# Altium schematic sheet Mega+ESP.SchDoc
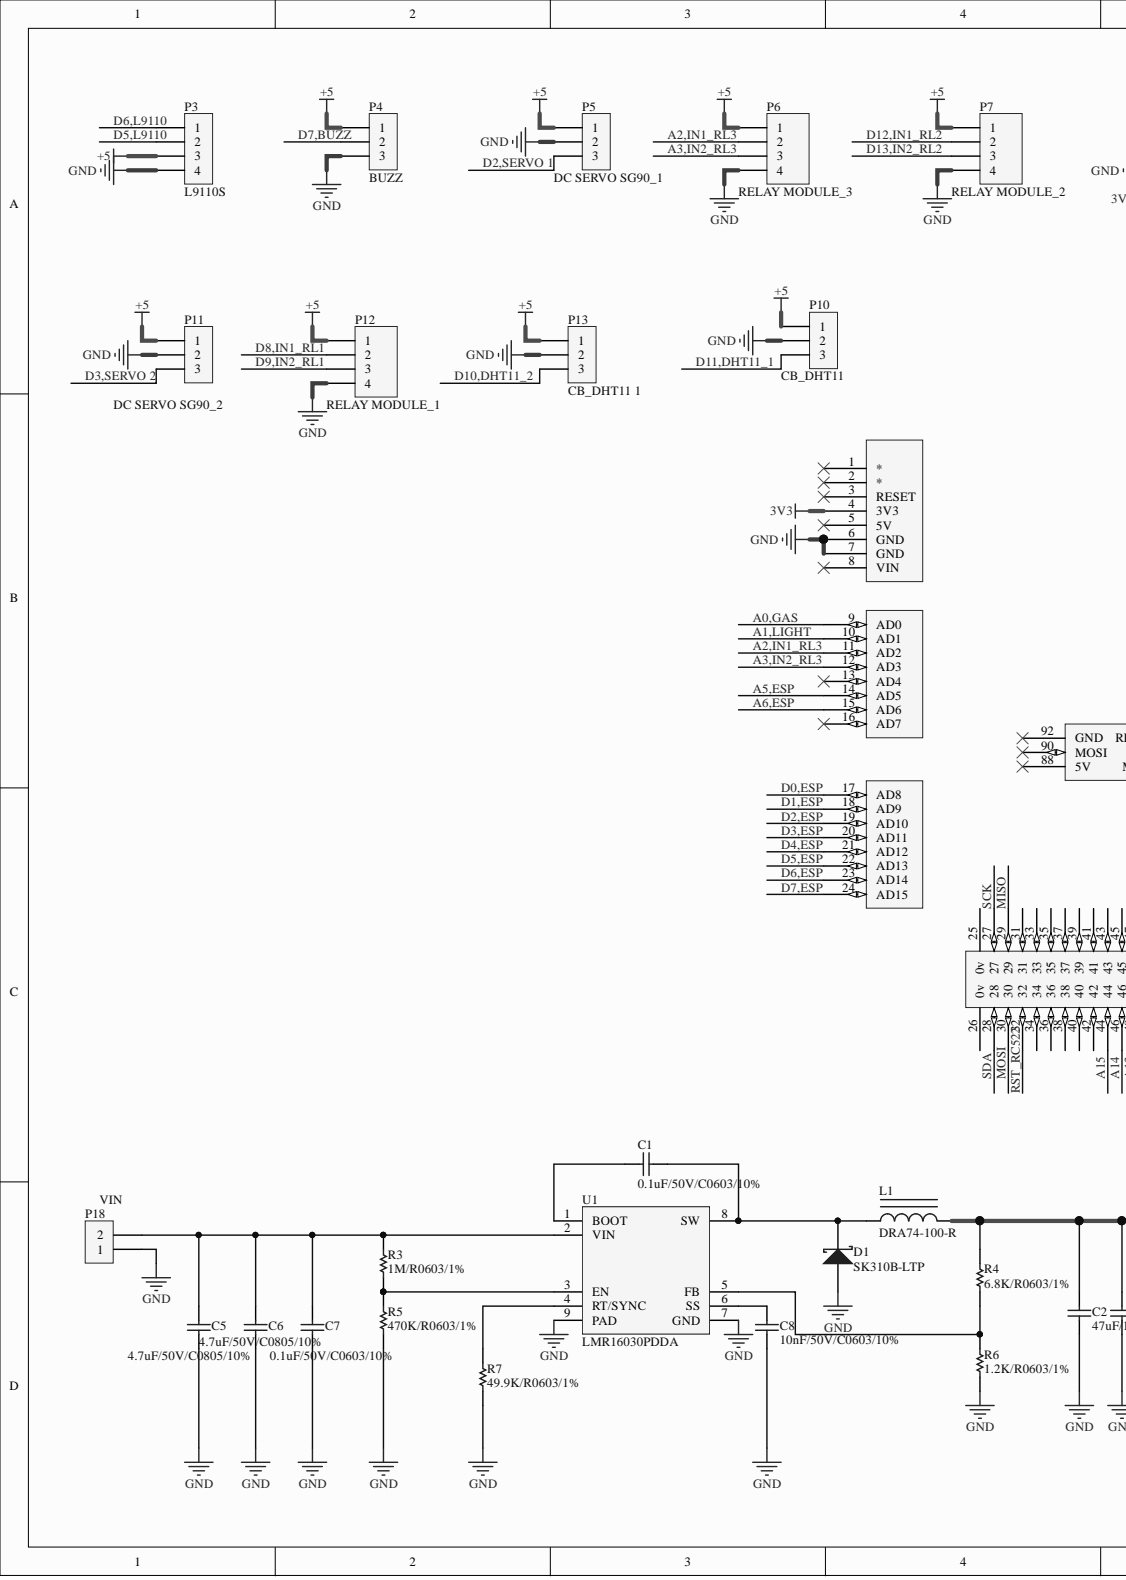
[diagram p0: Mega+ESP.SchDoc, left]
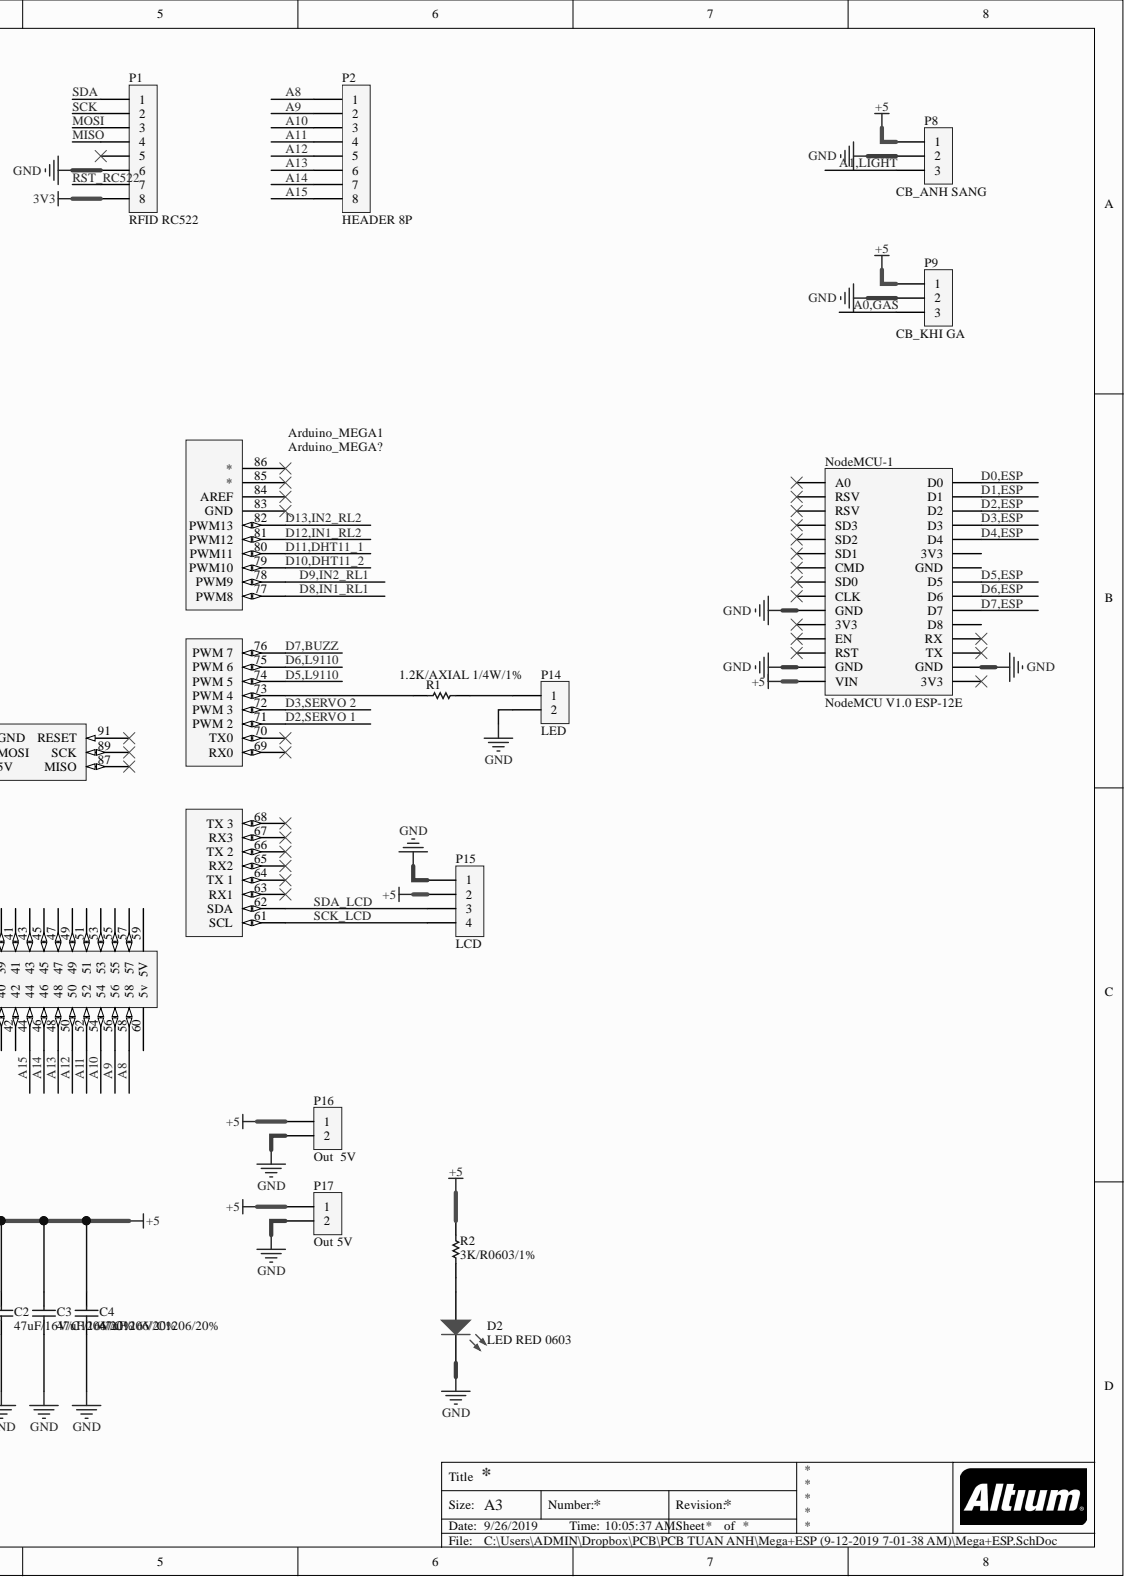
[diagram p1: Mega+ESP.SchDoc, right]

## Parts
Arduino_MEGA1  Arduino_MEGA?  [Arduino Mega]
C1  0.1uF/50V/C0603/10%  [C0603]
C2  47uF/16V/C1206/20%  [C1206]
C3  47uF/16V/C1206/20%  [C1206]
C4  47uF/16V/C1206/20%  [C1206]
C5  4.7uF/50V/C0805/10%  [C0805]
C6  4.7uF/50V/C0805/10%  [C0805]
C7  0.1uF/50V/C0603/10%  [C0603]
C8  10nF/50V/C0603/10%  [C0603]
D1  SK310B-LTP  [SMB (DO-214AA)]
D2  LED RED 0603  [LED RED 0603]
L1  DRA74-100-R  [INDUCTOR SMD DRA74 7.6X7.6X4.35mm]
NodeMCU-1  NodeMCU V1.0 ESP-12E  [NODEMCU V1.0 ESP-12E]
P1  RFID RC522  [HDR1X8]
P2  HEADER 8P  [HDR1X8]
P3  L9110S  [Domino P3.81-4Pin]
P4  BUZZ  [Domino P3.81-3Pin]
P5  DC SERVO SG90_1  [HDR1X3]
P6  RELAY MODULE_3  [Module Relay 2CH]
P7  RELAY MODULE_2  [Module Relay 2CH]
P8  CB_ANH SANG  [Domino P3.81-3Pin]
P9  CB_KHI GA  [Domino P3.81-3Pin]
P10  CB_DHT11  [Domino P3.81-3Pin]
P11  DC SERVO SG90_2  [HDR1X3]
P12  RELAY MODULE_1  [Module Relay 2CH]
P13  CB_DHT11 1  [Domino P3.81-3Pin]
P14  LED  [Header 5pin]
P15  LCD  [Domino P3.81-4Pin]
P16  Out  5V  [Domino P3.81-2Pin]
P17  Out 5V  [Domino P3.81-2Pin]
P18  VIN  [Domino P3.81-2Pin]
R1  1.2K/AXIAL 1/4W/1%  [R DIP1/4W-H]
R2  3K/R0603/1%  [R0603]
R3  1M/R0603/1%  [R0603]
R4  6.8K/R0603/1%  [R0603]
R5  470K/R0603/1%  [R0603]
R6  1.2K/R0603/1%  [R0603]
R7  49.9K/R0603/1%  [R0603]
U1  LMR16030PDDA  [SOIC-8-EP]

## Nets
3V3: Arduino_MEGA1.4(3V3) P1.8
+5: C2.2 C3.2 C4.2 L1.2 NodeMCU-1.VIN P3.3 P4.1 P5.1 P6.1 P7.1 P8.1 P9.1 P10.1 P11.1 P12.1 P13.1 P15.2 P16.1 P17.1 R2.1 R4.2
GND: Arduino_MEGA1.6(GND) Arduino_MEGA1.7(GND) C2.1 C3.1 C4.1 C5.1 C6.1 C7.1 C8.1 D1.1 D2.2 NodeMCU-1.GND P1.6 P3.4 P4.3 P5.2 P6.4 P7.4 P8.2 P9.2 P10.2 P11.2 P12.4 P13.2 P14.2 P15.1 P16.2 P17.2 P18.1 R5.1 R6.1 R7.1 U1.7(GND) U1.9(PAD)
A0,GAS: Arduino_MEGA1.9(AD0) P9.3
A1,LIGHT: Arduino_MEGA1.10(AD1) P8.3
A2,IN1_RL3: Arduino_MEGA1.11(AD2) P6.2
A3,IN2_RL3: Arduino_MEGA1.12(AD3) P6.3
A5,ESP: Arduino_MEGA1.14(AD5)
A6,ESP: Arduino_MEGA1.15(AD6)
A8: Arduino_MEGA1.58 P2.1
A9: Arduino_MEGA1.56 P2.2
A10: Arduino_MEGA1.54 P2.3
A11: Arduino_MEGA1.52 P2.4
A12: Arduino_MEGA1.50 P2.5
A13: Arduino_MEGA1.48 P2.6
A14: Arduino_MEGA1.46 P2.7
A15: Arduino_MEGA1.44 P2.8
D0,ESP: Arduino_MEGA1.17(AD8) NodeMCU-1.D0
D1,ESP: Arduino_MEGA1.18(AD9) NodeMCU-1.D1
D2,ESP: Arduino_MEGA1.19(AD10) NodeMCU-1.D2
D2,SERVO 1: Arduino_MEGA1.71(PWM_2) P5.3
D3,ESP: Arduino_MEGA1.20(AD11) NodeMCU-1.D3
D3,SERVO 2: Arduino_MEGA1.72(PWM_3) P11.3
D4,ESP: Arduino_MEGA1.21(AD12) NodeMCU-1.D4
D5,ESP: Arduino_MEGA1.22(AD13) NodeMCU-1.D5
D5,L9110: Arduino_MEGA1.74(PWM_5) P3.2
D6,ESP: Arduino_MEGA1.23(AD14) NodeMCU-1.D6
D6,L9110: Arduino_MEGA1.75(PWM_6) P3.1
D7,BUZZ: Arduino_MEGA1.76(PWM_7) P4.2
D7,ESP: Arduino_MEGA1.24(AD15) NodeMCU-1.D7
D8,IN1_RL1: Arduino_MEGA1.77(PWM8) P12.2
D9,IN2_RL1: Arduino_MEGA1.78(PWM9) P12.3
D10,DHT11_2: Arduino_MEGA1.79(PWM10) P13.3
D11,DHT11_1: Arduino_MEGA1.80(PWM11) P10.3
D12,IN1_RL2: Arduino_MEGA1.81(PWM12) P7.2
D13,IN2_RL2: Arduino_MEGA1.82(PWM13) P7.3
MISO: Arduino_MEGA1.29 P1.4
MOSI: Arduino_MEGA1.30 P1.3
RST_RC522: Arduino_MEGA1.32 P1.7
SCK: Arduino_MEGA1.27 P1.2
SCK_LCD: Arduino_MEGA1.61(SCL) P15.4
SDA: Arduino_MEGA1.28 P1.1
SDA_LCD: Arduino_MEGA1.62(SDA) P15.3
NetArduino_MEGA1_73: Arduino_MEGA1.73(PWM_4) R1.1
NetC1_1: C1.1 U1.1(BOOT)
NetC1_2: C1.2 D1.2 L1.1 U1.8(SW)
NetC5_2: C5.2 C6.2 C7.2 P18.2 R3.2 U1.2(VIN)
NetC8_2: C8.2 U1.6(SS)
NetD2_1: D2.1 R2.2
NetP14_1: P14.1 R1.2
NetR3_1: R3.1 R5.2 U1.3(EN)
NetR4_1: R4.1 R6.2 U1.5(FB)
NetR7_2: R7.2 U1.4(RT/SYNC)

Not connected: Arduino_MEGA1 1(*) 2(*) 3(RESET) 5(5V) 8(VIN) 13(AD4) 16(AD7) 25 26(0v) 31 33 34 35 36 37 38 39 40 41 42 43 45 47 49 51 53 55 57 59 60(5v) 63(RX1) 64(TX_1) 65(RX2) 66(TX_2) 67(RX3) 68(TX_3) 69(RX0) 70(TX0) 83(GND) 84(AREF) 85(*) 86(*) 87(MISO) 88(5V) 89(SCK) 90(MOSI) 91(RESET) 92(GND); NodeMCU-1 3V3 A0 CLK CMD D8 EN RST RSV RX SD0 SD1 SD2 SD3 TX; P1 5

## Library data of the parts
Arduino_MEGA1 = Arduino_MEGA: Component Kind: Standard; Library Name: Sch_Project_2.SchLib
C1 C7 = 0.1uF/50V/C0603/10%: CAP CER 0.1UF 50V 10% X7R 0603; Manufacturer: Murata Electronics North America; Manufacturer Part Number: GRM188R71H104KA93D; Capacitance: 0.1µF; Applications: General Purpose; Category: Capacitors; Component Kind: Standard; ComponentLink1Description: http://www.murata.com/~/media/webrenewal/support/library/catalog/products/capacitor/mlcc/c02e.ashx; ComponentLink1URL: http://www.murata.com/~/media/webrenewal/support/library/catalog/products/capacitor/mlcc/c02e.ashx; Features: -; Height - Seated (Max): -; Lead Spacing: -; Lead Style: -; Mounting Type: Surface Mount, MLCC; Operating Temperature: -55°C ~ 125°C; Package / Case: 0603 (1608 Metric); Packaging: Tape & Reel (TR); Ratings: -; RoHS: RoHS Compliant; Size / Dimension: 0.063" L x 0.031" W (1.60mm x 0.80mm); Supplier 1: Digi-Key; Temperature Coefficient: X7R; Thickness (Max): 0.035" (0.90mm); Tolerance: ±10%; Voltage - Rated: 50V; Library Name: Manh_Capacitor.SchLib
  C1: Supplier Part Number 1: 490-1519-1-ND
  C7: Pricing 1: 4000=0.00759, 8000=0.00693, 12000=0.0066, 28000=0.00627, 100000=0.00454 (USD); Stock 1: 8995972
C2-C4 = 47uF/16V/C1206/20%: CAP CER 47UF 16V X5R 1206; Manufacturer: TDK Corporation; Manufacturer Part Number: C3216X5R1C476M160AB; Capacitance: 47µF; Tolerance: ±20%; Applications: General Purpose; Category: Capacitors; Component Kind: Standard; ComponentLink1Description: http://product.tdk.com/en/catalog/datasheets/mlcc_commercial_general_en.pdf; ComponentLink1URL: http://product.tdk.com/en/catalog/datasheets/mlcc_commercial_general_en.pdf; Features: -; Height - Seated (Max): -; Lead Spacing: -; Lead Style: -; Mounting Type: Surface Mount, MLCC; Operating Temperature: -55°C ~ 85°C; Package / Case: 1206 (3216 Metric); Packaging: Cut Tape (CT); Ratings: -; RoHS: RoHS Compliant; Size / Dimension: 0.126" L x 0.063" W (3.20mm x 1.60mm); Supplier 1: Digi-Key; Supplier Part Number 1: 445-6003-1-ND; Temperature Coefficient: X5R; Thickness (Max): 0.071" (1.80mm); Voltage - Rated: 16V; Library Name: Manh_Capacitor.SchLib
C5 C6 = 4.7uF/50V/C0805/10%: CAP CER 4.7UF 50V 10% X5R 0805; Manufacturer: TDK Corporation; Manufacturer Part Number: CGA4J3X5R1H475K125AB; Capacitance: 4.7µF; Applications: Automotive; Category: Capacitors; Component Kind: Standard; ComponentLink1Description: http://product.tdk.com/en/catalog/datasheets/mlcc_automotive_general_en.pdf; ComponentLink1URL: http://product.tdk.com/en/catalog/datasheets/mlcc_automotive_general_en.pdf; Features: -; Height - Seated (Max): -; Lead Spacing: -; Lead Style: -; Mounting Type: Surface Mount, MLCC; Operating Temperature: -55°C ~ 85°C; Package / Case: 0805 (2012 Metric); Packaging: Tape & Reel (TR); Pricing 1: 2000=0.176, 4000=0.165, 10000=0.154, 14000=0.15125, 50000=0.143, 100000=0.1375 (USD); Ratings: AEC-Q200; RoHS: RoHS Compliant; Size / Dimension: 0.079" L x 0.049" W (2.00mm x 1.25mm); Stock 1: 10000; Supplier 1: Digi-Key; Temperature Coefficient: X5R; Thickness (Max): 0.049" (1.25mm); Tolerance: ±10%; Voltage - Rated: 50V; Library Name: Manh_Capacitor.SchLib
C8 = 10nF/50V/C0603/10%: CAP CER 10000PF 50V X7R 0603; Manufacturer: Murata Electronics North America; Manufacturer Part Number: GRM188R71H103KA01D; Tolerance: ±10%; Applications: General Purpose; Capacitance: 10000pF; Category: Capacitors; Component Kind: Standard; ComponentLink1Description: http://www.murata.com/~/media/webrenewal/support/library/catalog/products/capacitor/mlcc/c02e.ashx; ComponentLink1URL: http://www.murata.com/~/media/webrenewal/support/library/catalog/products/capacitor/mlcc/c02e.ashx; Features: -; Height - Seated (Max): -; Lead Spacing: -; Lead Style: -; Mounting Type: Surface Mount, MLCC; Operating Temperature: -55°C ~ 125°C; Package / Case: 0603 (1608 Metric); Packaging: Cut Tape (CT); Ratings: -; RoHS: RoHS Compliant; Size / Dimension: 0.063" L x 0.031" W (1.60mm x 0.80mm); Supplier 1: Digi-Key; Supplier Part Number 1: 490-1512-1-ND; Temperature Coefficient: X7R; Thickness (Max): 0.035" (0.90mm); Voltage - Rated: 50V; Library Name: Manh_Capacitor.SchLib
D1 = SK310B-LTP: DIODE SCHOTTKY 100V 3A DO214AA; Manufacturer: Micro Commercial Co; Capacitance @ Vr, F: 250pF @ 4V, 1MHz; Current - Reverse Leakage @ Vr: 500µA @ 100V; Speed: Fast Recovery =< 500ns, > 200mA (Io); Voltage - Forward (Vf) (Max) @ If: 850mV @ 3A; Category: Discrete Semiconductor Products; Component Kind: Standard; ComponentLink1Description: http://www.mccsemi.com/up_pdf/SK32B-L~SK310B-L(DO-214AA).pdf; ComponentLink1URL: http://www.mccsemi.com/up_pdf/SK32B-L~SK310B-L(DO-214AA).pdf; Current - Average Rectified (Io): 3A; Diode Type: Schottky; Manufacturer Part Number: SK310B-LTP; Mounting Type: Surface Mount; Operating Temperature - Junction: -55°C ~ 150°C; Package / Case: DO-214AA, SMB; Packaging: Cut Tape (CT); Part Status: Active; Pricing 1: 1=0.6, 10=0.42, 25=0.3448, 100=0.276, 250=0.20072, 500=0.1631, 1000=0.12545 (USD); Reverse Recovery Time (trr): -; RoHS: RoHS Compliant; Stock 1: 101065; Supplier 1: Digi-Key; Supplier Device Package: DO-214AA (SMB); Supplier Part Number 1: SK310B-LTPMSCT-ND; Voltage - DC Reverse (Vr) (Max): 100V; Library Name: Manh_Diode.SchLib
D2 = LED RED 0603: LED RED CLEAR 0603 SMD; Manufacturer: Lite-On Inc.; Manufacturer Part Number: LTST-C190KRKT; Current - Test: 20mA; Lens Color: Colorless; Lens Style/Size: Rectangle with Flat Top, 1.10mm x 0.80mm; Millicandela Rating: 99mcd; Viewing Angle: 130°; Voltage - Forward (Vf) (Typ): 2V; Wavelength - Dominant: 631nm; Wavelength - Peak: 639nm; Category: Optoelectronics; Color: Red; Component Kind: Standard; ComponentLink1Description: http://optoelectronics.liteon.com/upload/download/DS-22-99-0151/S_110_LTST-C190KRKT.pdf; ComponentLink1URL: http://optoelectronics.liteon.com/upload/download/DS-22-99-0151/S_110_LTST-C190KRKT.pdf; Features: -; Height (Max): 0.80mm; Lens Transparency: Clear; Mounting Type: Surface Mount; Package / Case: 0603 (1608 Metric); Packaging: Digi-Reel®; RoHS: RoHS Compliant; Size / Dimension: 1.60mm L x 0.80mm W; Supplier 1: Digi-Key; Supplier Part Number 1: 160-1436-1-ND; Library Name: Manh_LED.SchLib
L1 = 10uH/2.56A/7.6X7.6X3.55mm: FIXED IND 10UH 2.56A 43 MOHM SMD; Manufacturer: Eaton Bussmann; Current - Saturation: 2.97A; DC Resistance (DCR): 43 mOhm; Frequency - Test: 100kHz; Inductance: 10µH; Shielding: Shielded; Tolerance: ±20%; Type: Wirewound; Category: Inductors, Coils, Chokes; Component Kind: Standard; ComponentLink1Description: http://www1.cooperbussmann.com/pdf/9190a0eb-f996-4faf-a4d6-7b76f954f32d.pdf; ComponentLink1URL: http://www1.cooperbussmann.com/pdf/9190a0eb-f996-4faf-a4d6-7b76f954f32d.pdf; Current Rating: 2.56A; Frequency - Self Resonant: -; Height - Seated (Max): 0.139" (3.55mm); Manufacturer Part Number: DRA74-100-R; Material - Core: -; Mounting Type: Surface Mount; Operating Temperature: -40°C ~ 165°C; Package / Case: Nonstandard; Packaging: Digi-Reel®; Q @ Freq: -; RoHS: RoHS Compliant; Size / Dimension: 0.299" L x 0.299" W (7.60mm x 7.60mm); Supplier 1: Digi-Key; Supplier Device Package: -; Supplier Part Number 1: 283-3623-1-ND; Library Name: Manh_Inductor.SchLib
NodeMCU-1 = NodeMCU V1.0 ESP-12E: NodeMCU V1.0 ESP8266 (ESP-12E); Component Kind: Standard; Library Name: Sch_Project_2.SchLib
P1 = DOMINO_8P_3.81MM: TERM BLK 8P SIDE ENT 3.81MM PCB; Manufacturer 1: Phoenix Contact; Manufacturer Part Number 1: 1727078; Approvals: UL; Connector Type: Screw; Current: 13.5A; Max Current Rating: 12A; Max Supply Voltage: 160V; Number of Circuits: 8; Number of Levels: 1; Stripping Length: 5mm; Test Voltage: 2.5kV; Voltage: 300V; Wire/Cable Gauge: 26AWG; Wire Gauge (Min): 16AWG; Color: Green; Component Kind: Standard; ComponentLink1Description: https://datasheet.ciiva.com/15169/getdatasheetpartid-177912-15169668.pdf?src-supplier=Verical; ComponentLink1URL: https://datasheet.ciiva.com/15169/getdatasheetpartid-177912-15169668.pdf?src-supplier=Verical; ComponentLink2Description: https://datasheet.ciiva.com/16071/1727078-16071832.pdf?src-supplier=Onlinecomponents.com; ComponentLink2URL: https://datasheet.ciiva.com/16071/1727078-16071832.pdf?src-supplier=Onlinecomponents.com; ComponentLink3Description: https://datasheet.ciiva.com/823/1727078-823829.pdf?src-supplier=Digi-Key; ComponentLink3URL: https://datasheet.ciiva.com/823/1727078-823829.pdf?src-supplier=Digi-Key; ComponentLink4Description: https://datasheet.ciiva.com/1118/100945-1118602.pdf?src-supplier=Element14; ComponentLink4URL: https://datasheet.ciiva.com/1118/100945-1118602.pdf?src-supplier=Element14; Contact Material: Tin; Current Rating: 13.5A; Depth: 7.3mm; Flammability Rating: UL94 V-0; Height: 12mm; Housing Color: Green; Housing Material: Polyamide; Insulation Material: Nylon; Lead Free: Lead Free; Length: 30.47mm; Max Operating Temperature: 100°C; Min Operating Temperature: -20°C; Mount: Through Hole; Number of Contacts: 8; Number of Pins: 8; Number of Poles: 8; Number of Positions: 8; Number of Positions Per Level: 8; Number of Rows: 1; Orientation: Straight; Package Quantity: 50; Packaging: Bulk; Pitch: 3.81mm; Pricing 1: 1=5.00000, 10=4.78200, 50=4.65140, 100=4.34700, 250=3.99924, 500=3.69496, 1000=3.52108, 2500=3.30374 (USD); Radiation Hardening: No; REACH SVHC: No SVHC; RoHS: Yes; Stock 1: 5691; Supplier 1: Digi-Key; Supplier Part Number 1: 277-1953-ND; Termination: Screw; Voltage Rating: 300V; Weight: 0.15062oz; Width: 30.47mm; Wire Gauge (Max): 26AWG; Library Name: Manh_Connector.SchLib
P2 = HEADER VERT 8POS 2.54MM: CONN HEADER VERT 8POS 2.54MM; Component Kind: Standard; Library Name: Manh_Connector.SchLib
P3 P15 = DOMINO_4P_3.81MM: TERM BLK 4P SIDE ENT 3.81MM PCB; Manufacturer 1: Phoenix Contact; Manufacturer Part Number 1: 1727036; Approvals: UL; Connector Type: Screw; Current: 13.5A; Max Current Rating: 12A; Max Supply Voltage: 160V; Number of Circuits: 4; Number of Levels: 1; Test Voltage: 2.5kV; Voltage: 300V; Wire/Cable Gauge: 26AWG; Color: Green; Component Kind: Standard; ComponentLink1Description: https://datasheet.ciiva.com/16071/1727036-16071822.pdf?src-supplier=Onlinecomponents.com; ComponentLink1URL: https://datasheet.ciiva.com/16071/1727036-16071822.pdf?src-supplier=Onlinecomponents.com; ComponentLink2Description: https://datasheet.ciiva.com/824/1727036-824335.pdf?src-supplier=Digi-Key; ComponentLink2URL: https://datasheet.ciiva.com/824/1727036-824335.pdf?src-supplier=Digi-Key; ComponentLink3Description: https://datasheet.ciiva.com/1120/1699405-1120565.pdf?src-supplier=Farnell; ComponentLink3URL: https://datasheet.ciiva.com/1120/1699405-1120565.pdf?src-supplier=Farnell; ComponentLink4Description: https://datasheet.ciiva.com/15169/getdatasheetpartid-136938-15169499.pdf?src-supplier=Verical; ComponentLink4URL: https://datasheet.ciiva.com/15169/getdatasheetpartid-136938-15169499.pdf?src-supplier=Verical; ComponentLink5Description: https://datasheet.ciiva.com/1118/1699405-1118598.pdf?src-supplier=Element14; ComponentLink5URL: https://datasheet.ciiva.com/1118/1699405-1118598.pdf?src-supplier=Element14; Contact Material: Tin; Current Rating: 13.5A; Depth: 7.3mm; Flammability Rating: UL94 V-0; Height: 12mm; Housing Color: Green; Housing Material: Polyamide; Insulation Material: Nylon; Lead Free: Lead Free; Length: 7.3mm; Max Operating Temperature: 100°C; Min Operating Temperature: -20°C; Mount: Through Hole; Number of Contacts: 4; Number of Pins: 4; Number of Poles: 4; Number of Positions: 4; Number of Positions Per Level: 4; Number of Rows: 1; Orientation: Straight; Package Quantity: 50; Packaging: Bulk; Pitch: 3.81mm; Pricing 1: 1=2.58000, 10=2.47500, 50=2.36780, 100=2.30320, 250=2.15252, 500=1.98030, 1000=1.82962, 2500=1.74354, 5000=1.63590 (USD); Radiation Hardening: No; REACH SVHC: No SVHC; RoHS: Yes; Stock 1: 1801; Supplier 1: Digi-Key; Supplier Part Number 1: 277-1949-ND; Termination: Screw; Voltage Rating: 300V; Weight: 0.070548oz; Width: 15.23mm; Wire Gauge (Max): 26AWG; Wire Gauge (Min): 26AWG; Library Name: Manh_Connector.SchLib
P4 P5 P8-P11 P13 = DOMINO_3P_3.81MM: TERM BLK 3P SIDE ENT 3.81MM PCB; Manufacturer 1: Phoenix Contact; Manufacturer Part Number 1: 1727023; Approvals: UL; Connector Type: Screw; Current: 13.5A; Max Current Rating: 12A; Max Supply Voltage: 160V; Number of Circuits: 3; Number of Levels: 1; Voltage: 300V; Wire/Cable Gauge: 26AWG; Wire Gauge (Max): 30AWG; Wire Gauge (Min): 16AWG; Color: Green; Component Kind: Standard; ComponentLink1Description: https://datasheet.ciiva.com/7053/100945-7053104.pdf?src-supplier=Newark; ComponentLink1URL: https://datasheet.ciiva.com/7053/100945-7053104.pdf?src-supplier=Newark; ComponentLink2Description: https://datasheet.ciiva.com/1120/1718832-1120564.pdf?src-supplier=Farnell; ComponentLink2URL: https://datasheet.ciiva.com/1120/1718832-1120564.pdf?src-supplier=Farnell; ComponentLink3Description: https://datasheet.ciiva.com/824/1727023-824310.pdf?src-supplier=Digi-Key; ComponentLink3URL: https://datasheet.ciiva.com/824/1727023-824310.pdf?src-supplier=Digi-Key; ComponentLink4Description: https://datasheet.ciiva.com/16071/1727023-16071820.pdf?src-supplier=Onlinecomponents.com; ComponentLink4URL: https://datasheet.ciiva.com/16071/1727023-16071820.pdf?src-supplier=Onlinecomponents.com; Contact Material: Tin; Current Rating: 13.5A; Depth: 7.3mm; Flammability Rating: UL94 V-0; Height: 12mm; Housing Color: Green; Housing Material: Polyamide; Insulation Material: Nylon; Lead Free: Lead Free; Length: 7.3mm; Mount: Through Hole; Number of Contacts: 3; Number of Pins: 3; Number of Poles: 3; Number of Positions: 3; Number of Positions Per Level: 3; Number of Rows: 1; Orientation: Straight; Package Quantity: 50; Packaging: Bulk; Pitch: 3.81mm; Pricing 1: 1=1.94000, 10=1.86000, 50=1.77880, 100=1.73020, 250=1.61700, 500=1.48764, 1000=1.37445, 2500=1.30978, 5000=1.22893 (USD); REACH SVHC: Unknown; RoHS: Yes; Stock 1: 2285; Supplier 1: Digi-Key; Supplier Part Number 1: 277-1948-ND; Termination: Screw; Voltage Rating: 300V; Weight: 0.058202oz; Width: 12mm; Library Name: Manh_Connector.SchLib
P6 P7 P12 = Header 4: Header, 4-Pin; Component Kind: Standard; LatestRevisionDate: 17-Jul-2002; LatestRevisionNote: Re-released for DXP Platform.; Library Name: Miscellaneous Connectors.IntLib; Signal Integrity: Connector; Publisher: Altium Limited
P14 P16-P18 = DOMINO_2P_3.81MM: TERM BLK 2P SIDE ENT 3.81MM PCB; Manufacturer 1: Phoenix Contact; Manufacturer Part Number 1: 1727010; Approvals: UL; Connector Type: Screw; Current: 13.5A; Max Current Rating: 12A; Max Supply Voltage: 160V; Number of Circuits: 2; Number of Levels: 1; Voltage: 300V; Wire/Cable Gauge: 26AWG; Wire Gauge (Min): 16AWG; Color: Green; Component Kind: Standard; ComponentLink1Description: https://datasheet.ciiva.com/824/1727010-824277.pdf?src-supplier=Digi-Key; ComponentLink1URL: https://datasheet.ciiva.com/824/1727010-824277.pdf?src-supplier=Digi-Key; ComponentLink2Description: https://datasheet.ciiva.com/15216/getdatasheetpartid-227074-15216409.pdf?src-supplier=Verical; ComponentLink2URL: https://datasheet.ciiva.com/15216/getdatasheetpartid-227074-15216409.pdf?src-supplier=Verical; ComponentLink3Description: https://datasheet.ciiva.com/16046/1727010-16046140.pdf?src-supplier=Onlinecomponents.com; ComponentLink3URL: https://datasheet.ciiva.com/16046/1727010-16046140.pdf?src-supplier=Onlinecomponents.com; ComponentLink4Description: https://datasheet.ciiva.com/26341/788566-da-01-cs-printklemme-1727010-250-st-26341111.pdf?src-supplier=Conrad; ComponentLink4URL: https://datasheet.ciiva.com/26341/788566-da-01-cs-printklemme-1727010-250-st-26341111.pdf?src-supplier=Conrad; ComponentLink5Description: https://datasheet.ciiva.com/1118/100945-1118596.pdf?src-supplier=Element14; ComponentLink5URL: https://datasheet.ciiva.com/1118/100945-1118596.pdf?src-supplier=Element14; Contact Material: Tin; Current Rating: 13.5A; Depth: 7.3mm; Flammability Rating: UL94 V-0; Height: 12mm; Housing Color: Green; Housing Material: Polyamide; Insulation Material: Nylon; Lead Free: Lead Free; Length: 7.3mm; Mount: Through Hole; Number of Contacts: 2; Number of Pins: 2; Number of Poles: 2; Number of Positions: 2; Number of Positions Per Level: 2; Number of Rows: 1; Orientation: Straight; Package Quantity: 50; Packaging: Bulk; Pitch: 3.81mm; Pricing 1: 1=1.29000, 10=1.23200, 50=1.17820, 100=1.14600, 250=1.07100, 500=0.98532, 1000=0.91035, 2500=0.86752, 5000=0.81397 (USD); Radiation Hardening: No; REACH SVHC: No SVHC; RoHS: Yes; Stock 1: 2711; Supplier 1: Digi-Key; Supplier Part Number 1: 277-1947-ND; Termination: Solder; Voltage Rating: 300V; Weight: 0.036685oz; Width: 12mm; Wire Gauge (Max): 26AWG; Library Name: Manh_Connector.SchLib
R1 = 100K/AXIAL 1/4W/1%: RES 100K OHM 1/4W 1% AXIAL; Manufacturer: Stackpole Electronics Inc.; Manufacturer Part Number: RNF14FTD100K; Power (Watts): 0.25W, 1/4W; Temperature Coefficient: ±100ppm/°C; Category: Resistors; Component Kind: Standard; ComponentLink1Description: http://www.seielect.com/Catalog/SEI-RNF_RNMF.pdf; ComponentLink1URL: http://www.seielect.com/Catalog/SEI-RNF_RNMF.pdf; Composition: Metal Film; Features: Flame Retardant Coating, Safety; Height: -; Number of Terminations: 2; Operating Temperature: -55°C ~ 155°C; Package / Case: Axial; Packaging: Cut Tape (CT); Resistance (Ohms): 100k; RoHS: RoHS Compliant; Size / Dimension: 0.093" Dia x 0.250" L (2.35mm x 6.35mm); Supplier 1: Digi-Key; Supplier Device Package: Axial; Supplier Part Number 1: RNF14FTD100KCT-ND; Tolerance: ±1%; Library Name: Manh_Resistor.SchLib
R2 = 3K/R0603/1%: RES SMD 3K OHM 1% 1/10W 0603; Manufacturer: Yageo; Manufacturer Part Number: RC0603FR-073KL; Power (Watts): 0.1W, 1/10W; Temperature Coefficient: ±100ppm/°C; Category: Resistors; Component Kind: Standard; ComponentLink1Description: http://www.yageo.com/documents/recent/PYu-RC_Group_51_RoHS_L_7.pdf; ComponentLink1URL: http://www.yageo.com/documents/recent/PYu-RC_Group_51_RoHS_L_7.pdf; Composition: Thick Film; Failure Rate: -; Features: Moisture Resistant; Height - Seated (Max): 0.022" (0.55mm); Operating Temperature: -55°C ~ 155°C; Package / Case: 0603 (1608 Metric); Packaging: Cut Tape (CT); Part Status: Active; Pricing 1: 1=0.1, 10=0.013, 25=0.0096, 50=0.0074, 100=0.0055, 250=0.00416, 500=0.00332, 1000=0.00245 (USD); Resistance (Ohms): 3k; RoHS: RoHS Compliant; Size / Dimension: 0.063" L x 0.031" W (1.60mm x 0.80mm); Stock 1: 1322257; Supplier 1: Digi-Key; Supplier Device Package: 0603; Supplier Part Number 1: 311-3.00KHRCT-ND; Tolerance: ±1%; Library Name: Manh_Resistor.SchLib
R3 = 1M/R0603/1%: RES SMD 1M OHM 1% 1/10W 0603; Manufacturer: Yageo; Manufacturer Part Number: RC0603FR-071ML; Power (Watts): 0.1W, 1/10W; Temperature Coefficient: ±100ppm/°C; Category: Resistors; Component Kind: Standard; ComponentLink1Description: http://www.yageo.com/NewPortal/yageodocoutput?fileName=/pdf/R-Chip/PYu-RC_Group_51_RoHS_L_3.pdf; ComponentLink1URL: http://www.yageo.com/NewPortal/yageodocoutput?fileName=/pdf/R-Chip/PYu-RC_Group_51_RoHS_L_3.pdf; Composition: Thick Film; Features: Moisture Resistant; Height: 0.022" (0.55mm); Number of Terminations: 2; Operating Temperature: -55°C ~ 155°C; Package / Case: 0603 (1608 Metric); Packaging: Cut Tape (CT); Resistance (Ohms): 1M; RoHS: RoHS Compliant; Size / Dimension: 0.063" L x 0.031" W (1.60mm x 0.80mm); Supplier 1: Digi-Key; Supplier Device Package: 0603; Supplier Part Number 1: 311-1.00MHRCT-ND; Tolerance: ±1%; Library Name: Manh_Resistor.SchLib
R4 = 6.8K/R0603/1%: RES SMD 6.8K OHM 1% 1/10W 0603; Manufacturer: Panasonic Electronic Components; Manufacturer Part Number: ERJ-3EKF6801V; Power (Watts): 0.1W, 1/10W; Temperature Coefficient: ±100ppm/°C; Category: Resistors; Component Kind: Standard; ComponentLink1Description: http://industrial.panasonic.com/www-cgi/jvcr13pz.cgi?E+PZ+3+AOA0002+ERJ3EKF6801V+7+WW; ComponentLink1URL: http://industrial.panasonic.com/www-cgi/jvcr13pz.cgi?E+PZ+3+AOA0002+ERJ3EKF6801V+7+WW; Composition: Thick Film; Features: Automotive AEC-Q200; Height: 0.022" (0.55mm); Number of Terminations: 2; Operating Temperature: -55°C ~ 155°C; Package / Case: 0603 (1608 Metric); Packaging: Digi-Reel®; Resistance (Ohms): 6.8k; RoHS: RoHS Compliant; Size / Dimension: 0.063" L x 0.031" W (1.60mm x 0.80mm); Supplier 1: Digi-Key; Supplier Device Package: 0603; Supplier Part Number 1: P6.80KHCT-ND; Tolerance: ±1%; Library Name: Manh_Resistor.SchLib
R5 = 470K/R0603/1%: RES SMD 470K OHM 1% 1/10W 0603; Manufacturer: Yageo; Manufacturer Part Number: RC0603FR-07470KL; Power (Watts): 0.1W, 1/10W; Temperature Coefficient: ±100ppm/°C; Category: Resistors; Component Kind: Standard; ComponentLink1Description: http://www.yageo.com/documents/recent/PYu-RC_Group_51_RoHS_L_7.pdf; ComponentLink1URL: http://www.yageo.com/documents/recent/PYu-RC_Group_51_RoHS_L_7.pdf; Composition: Thick Film; Failure Rate: -; Features: Moisture Resistant; Height - Seated (Max): 0.022" (0.55mm); Operating Temperature: -55°C ~ 155°C; Package / Case: 0603 (1608 Metric); Packaging: Cut Tape (CT); Part Status: Active; Pricing 1: 1=0.1, 10=0.013, 25=0.0096, 50=0.0074, 100=0.0055, 250=0.00416, 500=0.00332, 1000=0.00245 (USD); Resistance (Ohms): 470k; RoHS: RoHS Compliant; Size / Dimension: 0.063" L x 0.031" W (1.60mm x 0.80mm); Stock 1: 778171; Supplier 1: Digi-Key; Supplier Device Package: 0603; Supplier Part Number 1: 311-470KHRCT-ND; Tolerance: ±1%; Library Name: Manh_Resistor.SchLib
R6 = 1.2K/R0603/1%: RES SMD 1.2K OHM 1% 1/10W 0603; Manufacturer: Yageo; Manufacturer Part Number: RC0603FR-071K2L; Power (Watts): 0.1W, 1/10W; Temperature Coefficient: ±100ppm/°C; Category: Resistors; Component Kind: Standard; ComponentLink1Description: http://www.yageo.com/NewPortal/yageodocoutput?fileName=/pdf/R-Chip/PYu-RC_Group_51_RoHS_L_3.pdf; ComponentLink1URL: http://www.yageo.com/NewPortal/yageodocoutput?fileName=/pdf/R-Chip/PYu-RC_Group_51_RoHS_L_3.pdf; Composition: Thick Film; Features: Moisture Resistant; Height: 0.022" (0.55mm); Number of Terminations: 2; Operating Temperature: -55°C ~ 155°C; Package / Case: 0603 (1608 Metric); Packaging: Tape & Reel (TR); Resistance (Ohms): 1.2k; RoHS: RoHS Compliant; Size / Dimension: 0.063" L x 0.031" W (1.60mm x 0.80mm); Supplier 1: Digi-Key; Supplier Device Package: 0603; Supplier Part Number 1: 311-1.20KHRCT-ND; Tolerance: ±1%; Library Name: Manh_Resistor.SchLib
R7 = 49.9K/R0603/1%: RES SMD 49.9K OHM 1% 1/10W 0603; Manufacturer: Yageo; Manufacturer Part Number: RC0603FR-0749K9L; Power (Watts): 0.1W, 1/10W; Temperature Coefficient: ±100ppm/°C; Category: Resistors; Component Kind: Standard; ComponentLink1Description: http://www.yageo.com/NewPortal/yageodocoutput?fileName=/pdf/R-Chip/PYu-RC_Group_51_RoHS_L_3.pdf; ComponentLink1URL: http://www.yageo.com/NewPortal/yageodocoutput?fileName=/pdf/R-Chip/PYu-RC_Group_51_RoHS_L_3.pdf; Composition: Thick Film; Features: Moisture Resistant; Height: 0.022" (0.55mm); Number of Terminations: 2; Operating Temperature: -55°C ~ 155°C; Package / Case: 0603 (1608 Metric); Packaging: Cut Tape (CT); Resistance (Ohms): 49.9k; RoHS: RoHS Compliant; Size / Dimension: 0.063" L x 0.031" W (1.60mm x 0.80mm); Supplier 1: Digi-Key; Supplier Device Package: 0603; Supplier Part Number 1: 311-49.9KHRCT-ND; Tolerance: ±1%; Library Name: Manh_Resistor.SchLib
U1 = LMR16030PDDA: IC REG BUCK ADJ 3A 8SOPWRPD; Manufacturer: Texas Instruments; Frequency - Switching: 200kHz ~ 2.5MHz; Function: Step-Down; Number of Outputs: 1; Output Configuration: Positive; Output Type: Adjustable; Synchronous Rectifier: No; Voltage - Input (Max): 60V; Voltage - Input (Min): 4.3V; Voltage - Output (Max): 50V; Voltage - Output (Min/Fixed): 0.8V; Category: Integrated Circuits (ICs); Component Kind: Standard; ComponentLink1Description: http://www.ti.com/lit/ds/symlink/lmr16030.pdf; ComponentLink1URL: http://www.ti.com/lit/ds/symlink/lmr16030.pdf; Current - Output: 3A; Manufacturer Part Number: LMR16030PDDA; Mounting Type: Surface Mount; Operating Temperature: -40°C ~ 125°C (TJ); Package / Case: 8-PowerSOIC (0.154", 3.90mm Width); Packaging: Tube; Part Status: Active; Pricing 1: 1=5.58, 10=5.012, 100=4.1067, 500=3.49596, 1000=2.9484 (USD); RoHS: RoHS Compliant; Stock 1: 811; Supplier 1: Digi-Key; Supplier Device Package: 8-SO PowerPad; Supplier Part Number 1: 296-44513-5-ND; Topology: Buck; Library Name: Manh_MCU.SchLib
